# Altium schematic sheet 5_POWER.SchDoc(5_POWER)
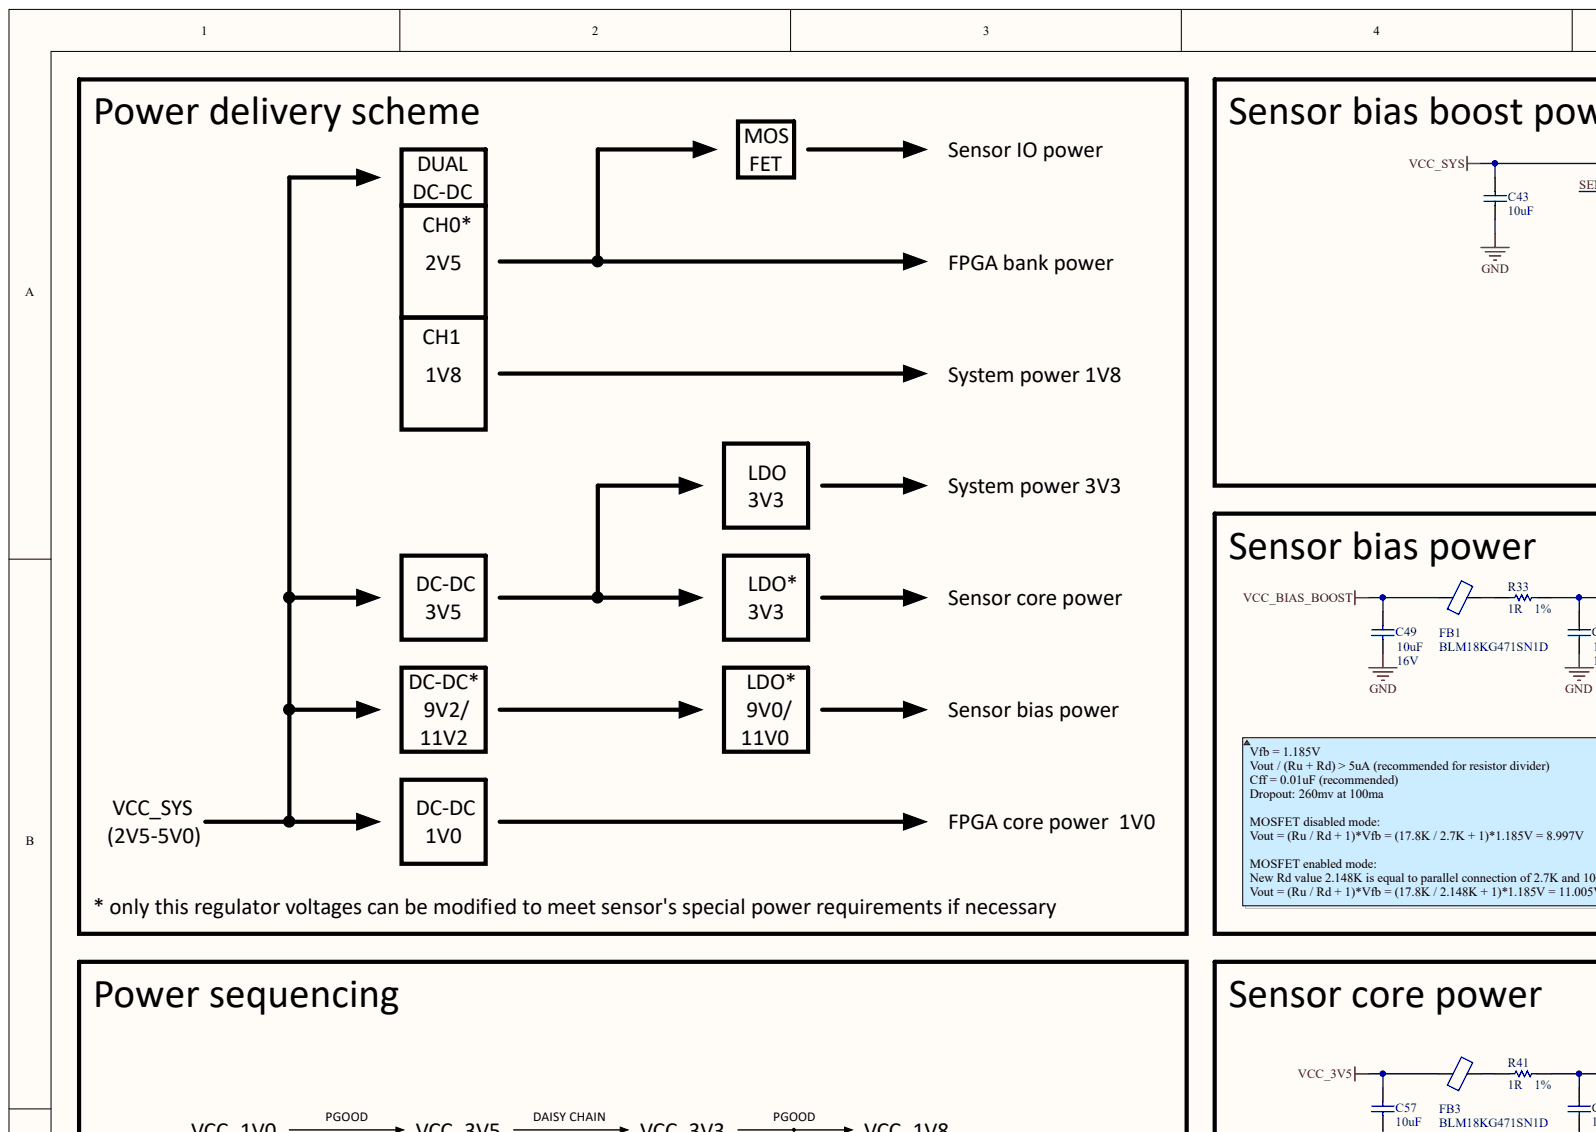
[diagram p0: 5_POWER.SchDoc, top left]
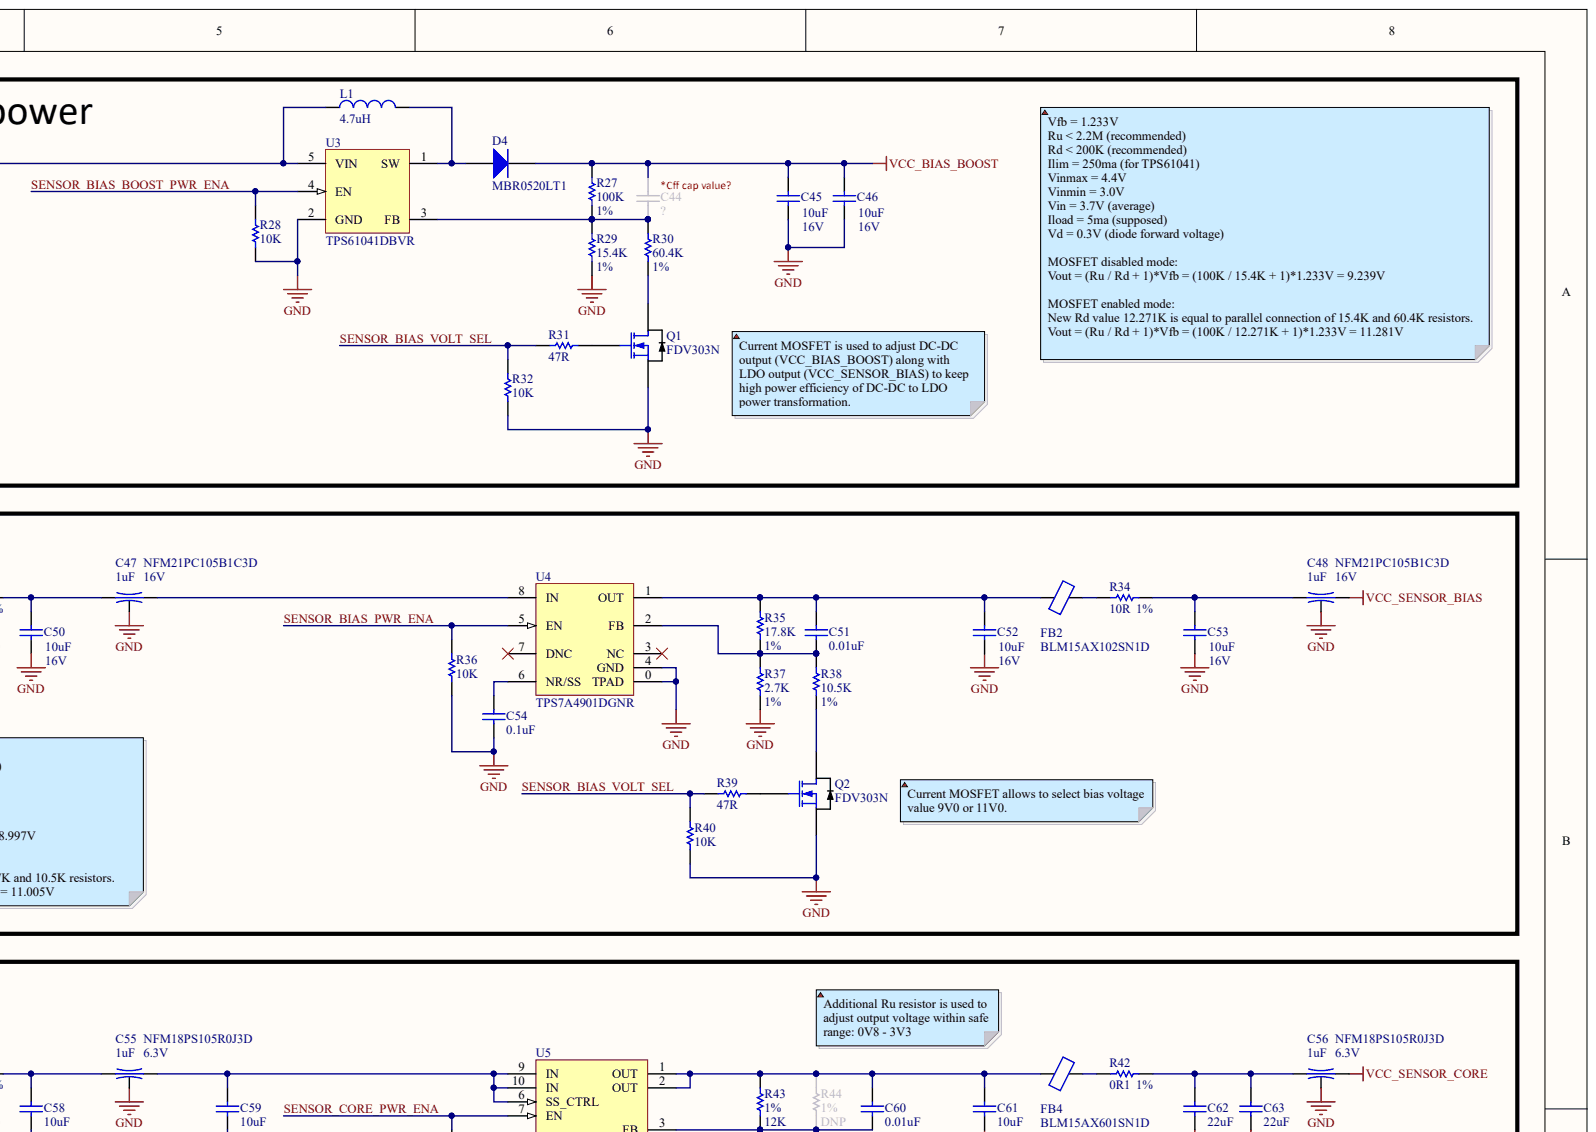
[diagram p1: 5_POWER.SchDoc, top right]
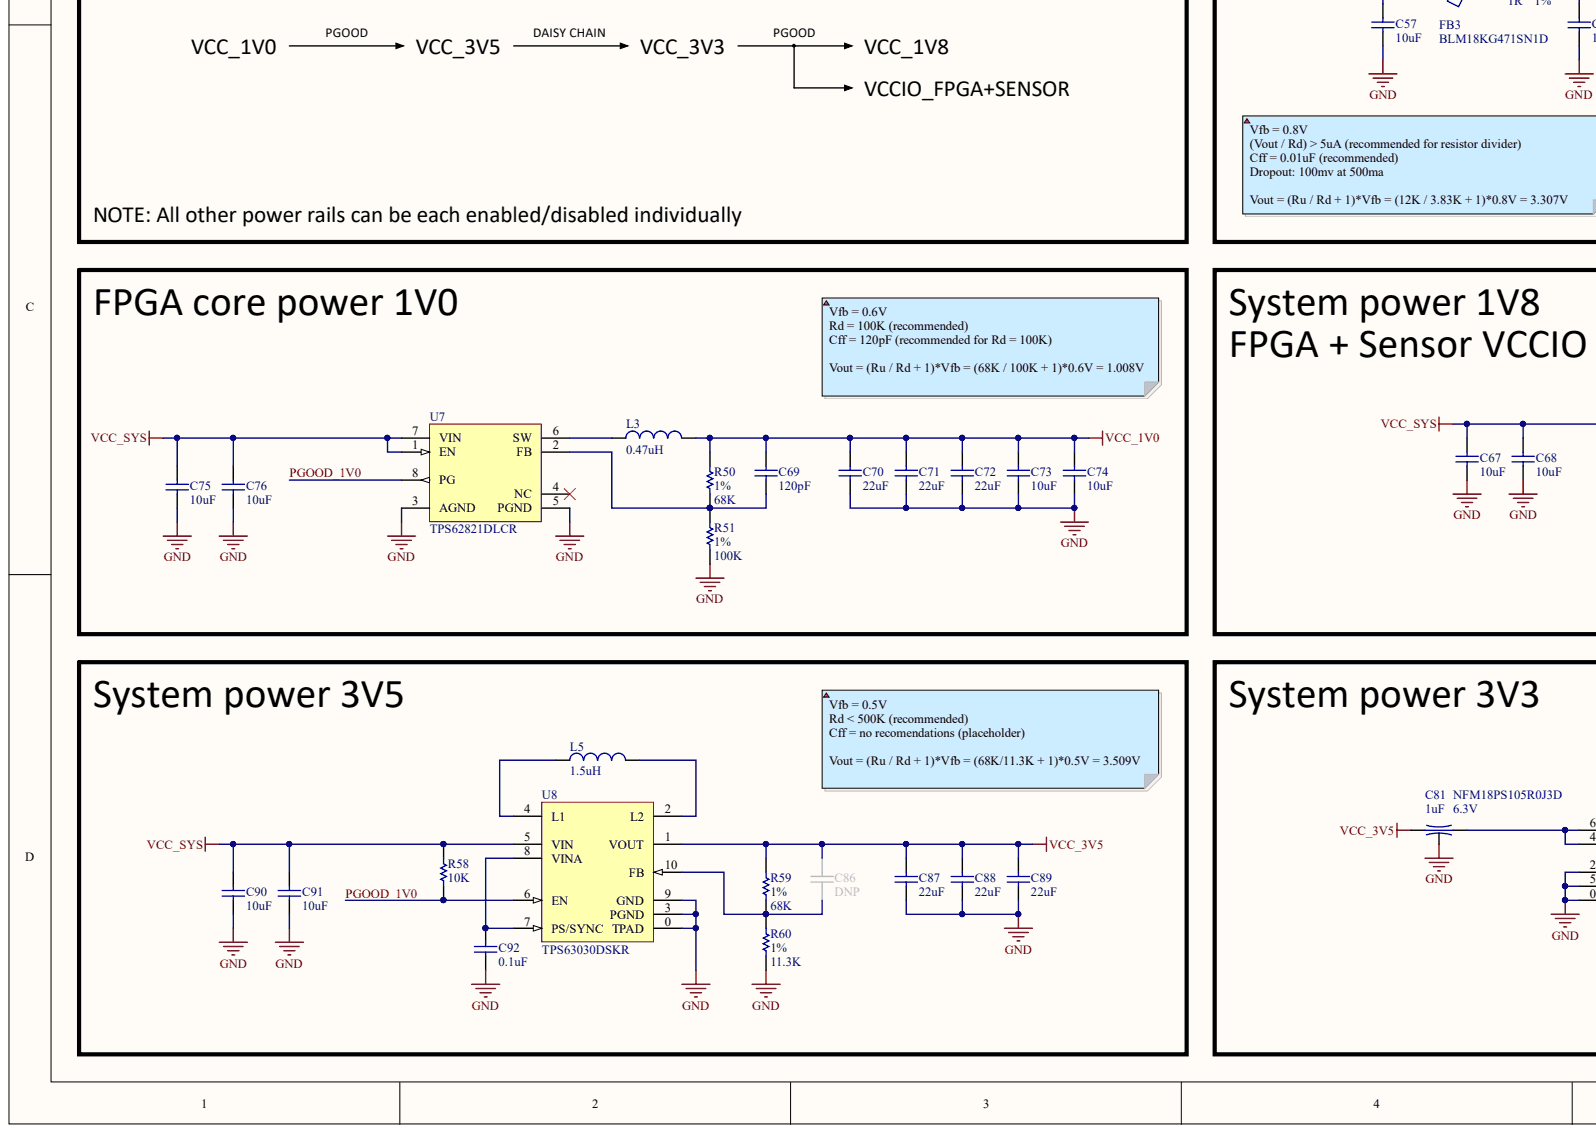
[diagram p2: 5_POWER.SchDoc, bottom left]
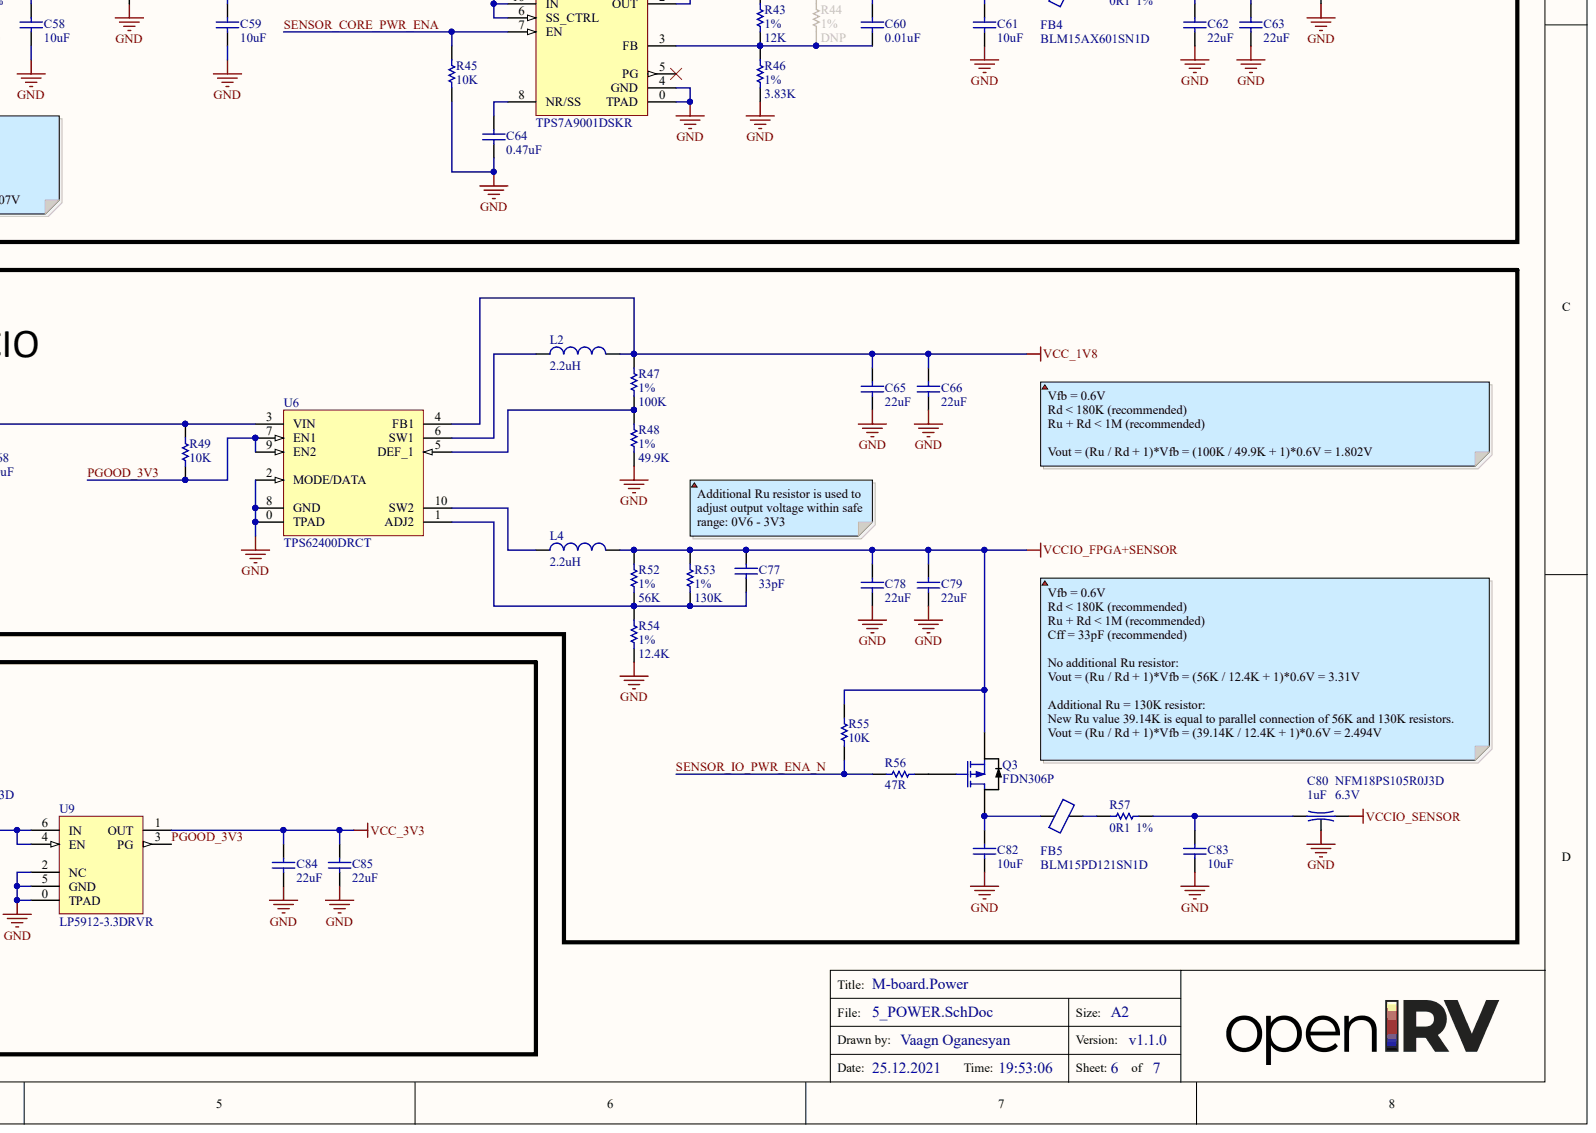
[diagram p3: 5_POWER.SchDoc, bottom right]

## Parts
C43  10uF  [C0805[2012]]
C44  ?  [C0402[1005]]
C45  10uF  Voltage: 16V  [C1206[3216]]
C46  10uF  Voltage: 16V  [C1206[3216]]
C47  1uF  ManufacturerPartNumber: NFM21PC105B1C3D  Voltage: 16V  [NFM21PC]
C48  1uF  ManufacturerPartNumber: NFM21PC105B1C3D  Voltage: 16V  [NFM21PC]
C49  10uF  Voltage: 16V  [C1206[3216]]
C50  10uF  Voltage: 16V  [C1206[3216]]
C51  0.01uF  [C0402[1005]]
C52  10uF  Voltage: 16V  [C1206[3216]]
C53  10uF  Voltage: 16V  [C1206[3216]]
C54  0.1uF  [C0402[1005]]
C55  1uF  ManufacturerPartNumber: NFM18PS105R0J3D  Voltage: 6.3V  [NFM18PS]
C56  1uF  ManufacturerPartNumber: NFM18PS105R0J3D  Voltage: 6.3V  [NFM18PS]
C57  10uF  [C0805[2012]]
C58  10uF  [C0805[2012]]
C59  10uF  [C0805[2012]]
C60  0.01uF  [C0402[1005]]
C61  10uF  [C0805[2012]]
C62  22uF  [C1206[3216]]
C63  22uF  [C1206[3216]]
C64  0.47uF  [C0402[1005]]
C65  22uF  [C1206[3216]]
C66  22uF  [C1206[3216]]
C67  10uF  [C0805[2012]]
C68  10uF  [C0805[2012]]
C69  120pF  [C0402[1005]]
C70  22uF  [C1206[3216]]
C71  22uF  [C1206[3216]]
C72  22uF  [C1206[3216]]
C73  10uF  [C0805[2012]]
C74  10uF  [C0805[2012]]
C75  10uF  [C0805[2012]]
C76  10uF  [C0805[2012]]
C77  33pF  [C0402[1005]]
C78  22uF  [C1206[3216]]
C79  22uF  [C1206[3216]]
C80  1uF  ManufacturerPartNumber: NFM18PS105R0J3D  Voltage: 6.3V  [NFM18PS]
C81  1uF  ManufacturerPartNumber: NFM18PS105R0J3D  Voltage: 6.3V  [NFM18PS]
C82  10uF  [C0805[2012]]
C83  10uF  [C0805[2012]]
C84  22uF  [C1206[3216]]
C85  22uF  [C1206[3216]]
C86  DNP  [C0402[1005]]
C87  22uF  [C1206[3216]]
C88  22uF  [C1206[3216]]
C89  22uF  [C1206[3216]]
C90  10uF  [C0805[2012]]
C91  10uF  [C0805[2012]]
C92  0.1uF  [C0402[1005]]
D4  MBR0520LT1  [MBR0520LT1]
FB1  BLM18KG471SN1D  [L0603[1608]]
FB2  BLM15AX102SN1D  [L0402[1005]]
FB3  BLM18KG471SN1D  [L0603[1608]]
FB4  BLM15AX601SN1D  [L0402[1005]]
FB5  BLM15PD121SN1D  [L0402[1005]]
L1  value 4.7uH  [L0805[2012]]  (hidden comment: LQM21PN4R7MGRD)
L2  value 2.2uH  [LQM2HPNXXXXG0X]  (hidden comment: LQM2HPN2R2MG0L)
L3  value 0.47uH  [DFE252012P-XXXM=P2]  (hidden comment: DFE252012P-R47M=P2)
L4  value 2.2uH  [LQM2HPNXXXXG0X]  (hidden comment: LQM2HPN2R2MG0L)
L5  value 1.5uH  [LQM2HPNXXXXG0X]  (hidden comment: LQM2HPN1R5MG0L)
Q1  FDV303N  [FDV303N]
Q2  FDV303N  [FDV303N]
Q3  FDN306P  [FDN306P]
R27  100K  Tolerance: 1%  [R0603[1608]]
R28  10K  [R0402[1005]]
R29  15.4K  Tolerance: 1%  [R0603[1608]]
R30  60.4K  Tolerance: 1%  [R0603[1608]]
R31  47R  [R0402[1005]]
R32  10K  [R0402[1005]]
R33  1R  Tolerance: 1%  [R0603[1608]]
R34  10R  Tolerance: 1%  [R0603[1608]]
R35  17.8K  Tolerance: 1%  [R0603[1608]]
R36  10K  [R0402[1005]]
R37  2.7K  Tolerance: 1%  [R0603[1608]]
R38  10.5K  Tolerance: 1%  [R0603[1608]]
R39  47R  [R0402[1005]]
R40  10K  [R0402[1005]]
R41  1R  Tolerance: 1%  [R0603[1608]]
R42  0R1  Tolerance: 1%  [R0603[1608]]
R43  12K  Tolerance: 1%  [R0603[1608]]
R44  DNP  Tolerance: 1%  [R0603[1608]]
R45  10K  [R0402[1005]]
R46  3.83K  Tolerance: 1%  [R0603[1608]]
R47  100K  Tolerance: 1%  [R0603[1608]]
R48  49.9K  Tolerance: 1%  [R0603[1608]]
R49  10K  [R0402[1005]]
R50  68K  Tolerance: 1%  [R0603[1608]]
R51  100K  Tolerance: 1%  [R0603[1608]]
R52  56K  Tolerance: 1%  [R0603[1608]]
R53  130K  Tolerance: 1%  [R0603[1608]]
R54  12.4K  Tolerance: 1%  [R0603[1608]]
R55  10K  [R0402[1005]]
R56  47R  [R0402[1005]]
R57  0R1  Tolerance: 1%  [R0603[1608]]
R58  10K  [R0402[1005]]
R59  68K  Tolerance: 1%  [R0603[1608]]
R60  11.3K  Tolerance: 1%  [R0603[1608]]
U3  TPS61041DBVR  [TPS61041DBVR]
U4  TPS7A4901DGNR  [TPS7A4901DGNR]
U5  TPS7A9001DSKR  [TPS7A9001DSKR]
U6  TPS62400DRCT  [TPS62400DRCT]
U7  TPS62821DLCR  [TPS62821DLCR]
U8  TPS63030DSKR  [TPS63030DSKR]
U9  LP5912-3.3DRVR  [LP5912-3.3DRVR]

## Nets
GND: C43.1 C45.1 C46.1 C47.2 C48.2 C49.1 C50.1 C52.1 C53.1 C54.2 C55.2 C56.2 C57.1 C58.1 C59.1 C61.1 C62.1 C63.1 C64.2 C65.1 C66.1 C67.1 C68.1 C70.1 C71.1 C72.1 C73.1 C74.1 C75.1 C76.1 C78.1 C79.1 C80.2 C81.2 C82.1 C83.1 C84.1 C85.1 C87.1 C88.1 C89.1 C90.1 C91.1 C92.2 Q1.2 Q2.2 R28.1 R29.1 R32.1 R36.1 R37.1 R40.1 R45.1 R46.2 R48.2 R51.2 R54.2 R60.2 U3.2(GND) U4.0(TPAD) U4.4(GND) U5.0(TPAD) U5.4(GND) U6.0(TPAD) U6.2(MODE/DATA) U6.8(GND) U7.3(AGND) U7.5(PGND) U8.0(TPAD) U8.3(PGND) U8.9(GND) U9.0(TPAD) U9.2(NC) U9.5(GND)
VCC_1V0: C69.1 C70.2 C71.2 C72.2 C73.2 C74.2 L3.2 R50.1
VCC_1V8: C65.2 C66.2 L2.2 R47.1 U6.4(FB1)
VCC_3V3: C84.2 C85.2 U9.1(OUT)
VCC_3V5: C57.2 C81.1 C86.1 C87.2 C88.2 C89.2 FB3.1 R59.1 U8.1(VOUT)
VCC_BIAS_BOOST: C44.2 C45.2 C46.2 C49.2 D4.2 FB1.1 R27.2
VCC_SENSOR_BIAS: C48.3
VCC_SENSOR_CORE: C56.3
VCC_SYS: C43.2 C67.2 C68.2 C75.2 C76.2 C90.2 C91.2 L1.1 R49.2 R58.2 U3.5(VIN) U6.3(VIN) U7.1(EN) U7.7(VIN) U8.5(VIN)
VCCIO_FPGA+SENSOR: C77.1 C78.2 C79.2 L4.2 Q3.2 R52.1 R53.1 R55.2
VCCIO_SENSOR: C80.3
PGOOD_1V0: R58.1 U7.8(PG) U8.6(EN)
PGOOD_3V3: R49.1 U6.7(EN1) U6.9(EN2) U9.3(PG)
SENSOR_BIAS_BOOST_PWR_ENA: R28.2 U3.4(EN)
SENSOR_BIAS_PWR_ENA: R36.2 U4.5(EN)
SENSOR_BIAS_VOLT_SEL: R31.1 R32.2 R39.1 R40.2
SENSOR_CORE_PWR_ENA: R45.2 U5.7(EN)
SENSOR_IO_PWR_ENA_N: R55.1 R56.1
NetC44_1: C44.1 R27.1 R29.2 R30.2 U3.3(FB)
NetC47_1: C47.1 C50.2 R33.1
NetC47_3: C47.3 U4.8(IN)
NetC48_1: C48.1 C53.2 R34.1
NetC51_1: C51.1 C52.2 FB2.1 R35.2 U4.1(OUT)
NetC51_2: C51.2 R35.1 R37.2 R38.2 U4.2(FB)
NetC54_1: C54.1 U4.6(NR/SS)
NetC55_1: C55.1 C58.2 R41.1
NetC55_3: C55.3 C59.2 U5.6(SS_CTRL) U5.9(IN) U5.10(IN)
NetC56_1: C56.1 C62.2 C63.2 R42.1
NetC60_1: C60.1 C61.2 FB4.1 R43.1 R44.1 U5.1(OUT) U5.2(OUT)
NetC60_2: C60.2 R43.2 R44.2 R46.1 U5.3(FB)
NetC64_1: C64.1 U5.8(NR/SS)
NetC69_2: C69.2 R50.2 R51.1 U7.2(FB)
NetC77_2: C77.2 R52.2 R53.2 R54.1 U6.1(ADJ2)
NetC80_1: C80.1 C83.2 R57.1
NetC81_3: C81.3 U9.4(EN) U9.6(IN)
NetC82_2: C82.2 FB5.1 Q3.3
NetC86_2: C86.2 R59.2 R60.1 U8.10(FB)
NetC92_1: C92.1 U8.7(PS/SYNC) U8.8(VINA)
NetD4_1: D4.1 L1.2 U3.1(SW)
NetFB1_2: FB1.2 R33.2
NetFB2_2: FB2.2 R34.2
NetFB3_2: FB3.2 R41.2
NetFB4_2: FB4.2 R42.2
NetFB5_2: FB5.2 R57.2
NetL2_1: L2.1 U6.6(SW1)
NetL3_1: L3.1 U7.6(SW)
NetL4_1: L4.1 U6.10(SW2)
NetL5_1: L5.1 U8.4(L1)
NetL5_2: L5.2 U8.2(L2)
NetQ1_1: Q1.1 R31.2
NetQ1_3: Q1.3 R30.1
NetQ2_1: Q2.1 R39.2
NetQ2_3: Q2.3 R38.1
NetQ3_1: Q3.1 R56.2
NetR47_2: R47.2 R48.1 U6.5(DEF_1)

Not connected: U4 3(NC) 7(DNC); U5 5(PG); U7 4(NC)

## Library data of the parts
C43 C57-C59 C61-C63 C65-C68 C70-C76 C78 C79 C82-C85 C87-C91 = CAP: Dielectric: X7R; Tolerance: 10%; Voltage: 10V; Component Kind: Standard; Library Name: general.IntLib; Mounting: SMD
  C43 C57-C59 C61 C67 C68 C73-C76 C82 C83 C90 C91: Value: 10uF
  C62 C63 C65 C66 C70-C72 C78 C79 C84 C85 C87-C89: Value: 22uF
C44 C69 C86 = CAP: Dielectric: X7R; Tolerance: 10%; Voltage: 50v; Component Kind: Standard; Library Name: general.IntLib; Mounting: SMD
  C44: Value: ?
  C69: Value: 120pF
  C86: Value: DNP
C45 C46 C49 C50 C52 C53 = CAP: Dielectric: X7R; Tolerance: 10%; Component Kind: Standard; Library Name: general.IntLib; Mounting: SMD; Value: 10uF
C47 C48 = 3T_CAP: Current: 4A; Tolerance: 20%; Component Kind: Standard; Library Name: general.IntLib; Mounting: SMD; Value: 1uF
C51 C54 C60 C92 = CAP: Dielectric: X7R; Tolerance: 10%; Voltage: 50V; Component Kind: Standard; Library Name: general.IntLib; Mounting: SMD
  C51 C60: Value: 0.01uF
  C54 C92: Value: 0.1uF
C55 C56 C80 C81 = 3T_CAP: Current: 2A; Tolerance: 20%; Component Kind: Standard; Library Name: general.IntLib; Mounting: SMD; Value: 1uF
C64 = CAP: Dielectric: X5R; Tolerance: 10%; Voltage: 6.3V; Component Kind: Standard; Library Name: general.IntLib; Mounting: SMD; Value: 0.47uF
C77 = CAP: Dielectric: NP0; Tolerance: 5%; Voltage: 50V; Component Kind: Standard; Library Name: general.IntLib; Mounting: SMD; Value: 33pF
D4 = Diode_1: Diode; Component Kind: Standard; Library Name: general.IntLib; ManufacturerPartNumber: MBR0520LT1
FB1 FB3 = Ferrite_bead: Current: 1.5A; DC resistance: 0R13; Impedance: 470R; Component Kind: Standard; Library Name: general.IntLib; ManufacturerPartNumber: BLM18KG471SN1D; Mounting: SMD
FB2 = Ferrite_bead: Current: 0.35A; DC resistance: 0R49; Impedance: 1000R; Component Kind: Standard; Library Name: general.IntLib; ManufacturerPartNumber: BLM15AX102SN1D; Mounting: SMD
FB4 = Ferrite_bead: Current: 0.5A; DC resistance: 0R34; Impedance: 600R; Component Kind: Standard; Library Name: general.IntLib; ManufacturerPartNumber: BLM15AX601SN1D; Mounting: SMD
FB5 = Ferrite_bead: Current: 1.3A; DC resistance: 0R09; Impedance: 120R; Component Kind: Standard; Library Name: general.IntLib; ManufacturerPartNumber: BLM15PD121SN1D; Mounting: SMD
L1-L5 = Inductor: Component Kind: Standard; Library Name: general.IntLib
  L1: ManufacturerPartNumber: LQM21PN4R7MGRD
  L2 L4: ManufacturerPartNumber: LQM2HPN2R2MG0L
  L3: ManufacturerPartNumber: DFE252012P-R47M=P2
  L5: ManufacturerPartNumber: LQM2HPN1R5MG0L
R27 R29 R30 R33-R35 R37 R38 R41-R44 R46-R48 R50-R54 R57 R59 R60 = RES: Power: 0.1W; Component Kind: Standard; Library Name: general.IntLib; Mounting: SMD
  R27 R47 R51: Value: 100K
  R29: Value: 15.4K
  R30: Value: 60.4K
  R33 R41: Value: 1R
  R34: Value: 10R
  R35: Value: 17.8K
  R37: Value: 2.7K
  R38: Value: 10.5K
  R42 R57: Value: 0R1
  R43: Value: 12K
  R44: Value: DNP
  R46: Value: 3.83K
  R48: Value: 49.9K
  R50 R59: Value: 68K
  R52: Value: 56K
  R53: Value: 130K
  R54: Value: 12.4K
  R60: Value: 11.3K
R28 R31 R32 R36 R39 R40 R45 R49 R55 R56 R58 = RES: Power: 0.06W; Tolerance: 5%; Component Kind: Standard; Library Name: general.IntLib; Mounting: SMD
  R28 R32 R36 R40 R45 R49 R55 R58: Value: 10K
  R31 R39 R56: Value: 47R
U3 = TPS61041DBVR: DC-DC boost converter; Component Kind: Standard; Library Name: general.IntLib
U4 = TPS7A4901DGNR: Ultralow-Noise Positive LDO 150ma; Component Kind: Standard; Library Name: general.IntLib
U5 = TPS7A9001DSKR: LDO Low-Noise 500ma; Component Kind: Standard; Library Name: general.IntLib
U6 = TPS62400DRCT: Dual Step-Down DC-DC; Component Kind: Standard; Library Name: general.IntLib
U7 = TPS62821DLCR: DC-DC Step-Down Converter; Component Kind: Standard; Library Name: general.IntLib
U8 = TPS63030DSKR: DC-DC 1A Buck-Boost Converter; Component Kind: Standard; Library Name: general.IntLib
U9 = LP5912-3.3DRVR: LDO 500ma, Fixed 3.3 V; Component Kind: Standard; Library Name: general.IntLib
Q1 Q2 = FDV303N: Component Kind: Standard; Library Name: general.IntLib
Q3 = FDN306P: Component Kind: Standard; Library Name: general.IntLib
